# Altium schematic sheet Sata_SSD4.SchDoc(Sata_SSD4)
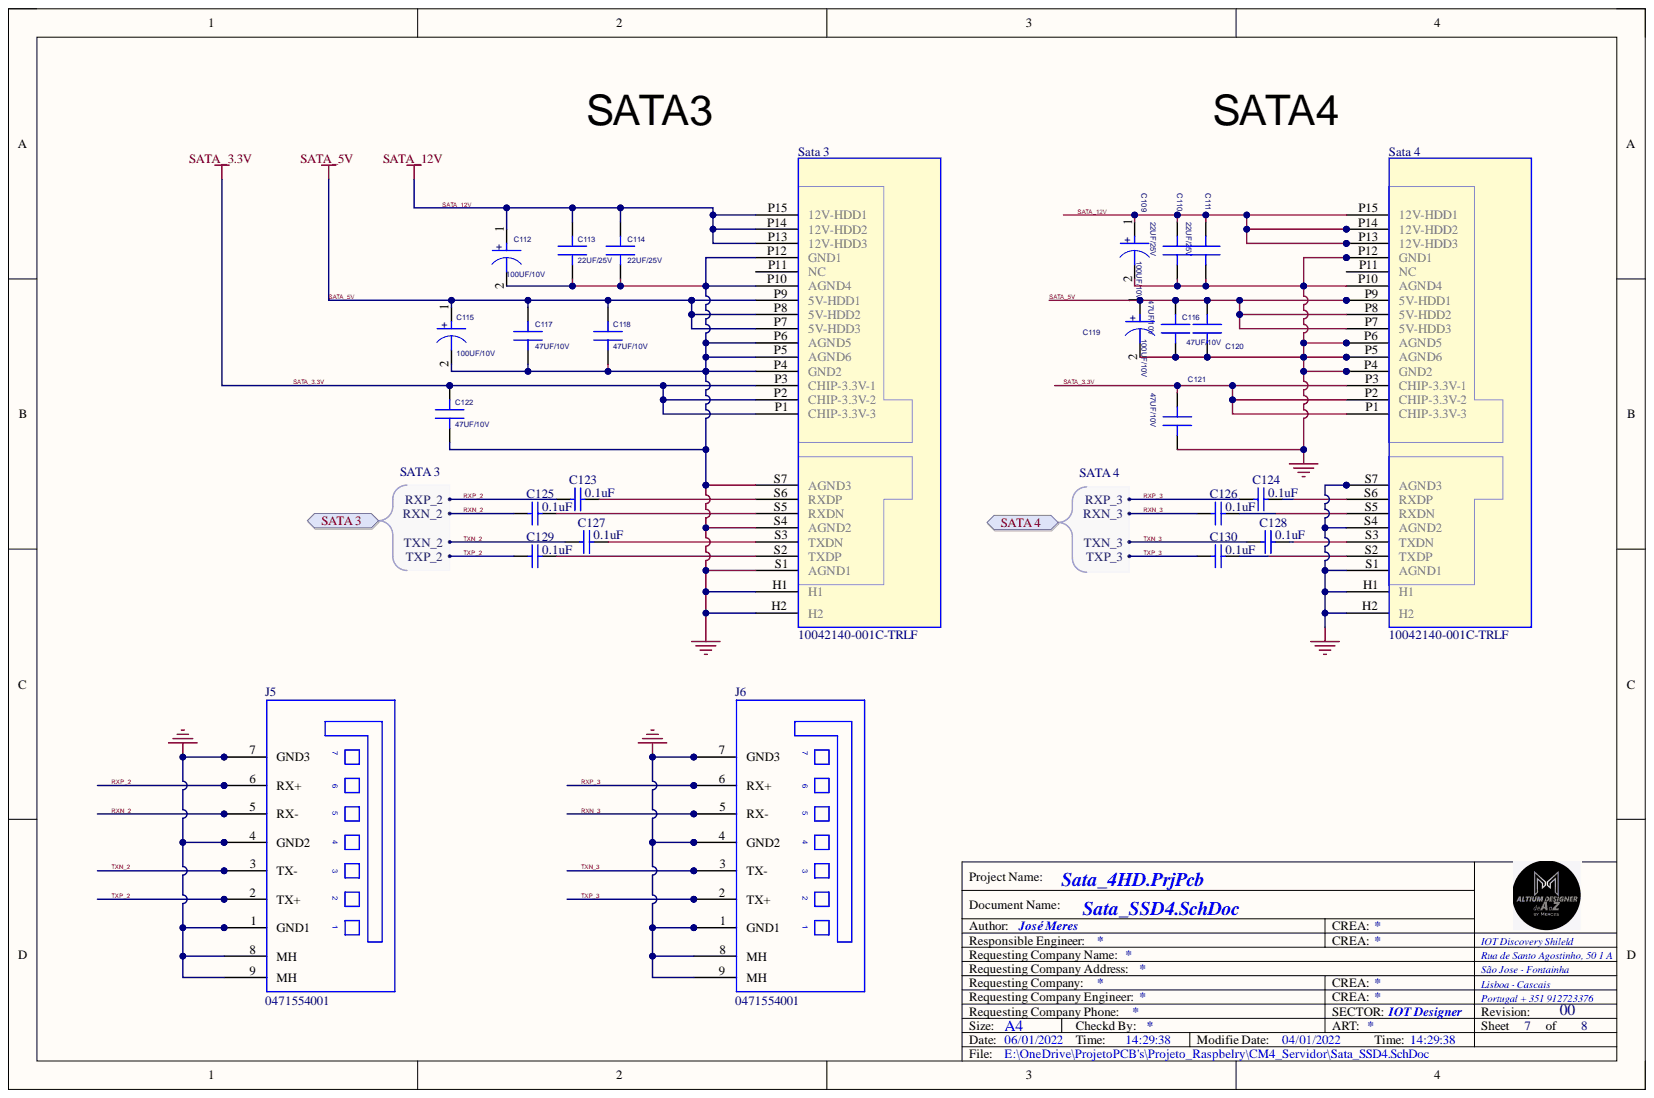
[diagram p0: Sata_SSD4.SchDoc]

## Parts
C109  100UF/10V  [Capacitor SMD Eletrolitic-D8.0x10]
C110  22UF/25V  [C0805-ROUND]
C111  22UF/25V  [C0805-ROUND]
C112  100UF/10V  [Capacitor SMD Eletrolitic-D8.0x10]
C113  22UF/25V  [C0805-ROUND]
C114  22UF/25V  [C0805-ROUND]
C115  100UF/10V  [Capacitor SMD Eletrolitic-D8.0x10]
C116  47UF/10V  [C0805-ROUND]
C117  47UF/10V  [C0805-ROUND]
C118  47UF/10V  [C0805-ROUND]
C119  100UF/10V  [Capacitor SMD Eletrolitic-D8.0x10]
C120  47UF/10V  [C0805-ROUND]
C121  47UF/10V  [C0805-ROUND]
C122  47UF/10V  [C0805-ROUND]
C123  value 0.1uF  [C0201-ROUND]  (hidden comment: GRM155R71A104KA01D)
C124  value 0.1uF  [C0201-ROUND]  (hidden comment: GRM155R71A104KA01D)
C125  value 0.1uF  [C0201-ROUND]  (hidden comment: GRM155R71A104KA01D)
C126  value 0.1uF  [C0201-ROUND]  (hidden comment: GRM155R71A104KA01D)
C127  value 0.1uF  [C0201-ROUND]  (hidden comment: GRM155R71A104KA01D)
C128  value 0.1uF  [C0201-ROUND]  (hidden comment: GRM155R71A104KA01D)
C129  value 0.1uF  [C0201-ROUND]  (hidden comment: GRM155R71A104KA01D)
C130  value 0.1uF  [C0201-ROUND]  (hidden comment: GRM155R71A104KA01D)
J5  0471554001  [SATA_VERTICAL]
J6  0471554001  [SATA_VERTICAL]
Sata_3  10042140-001C-TRLF  [sata_22p_smd_h6_h9]
Sata_4  10042140-001C-TRLF  [sata_22p_smd_h6_h9]

## Ports
SATA 3, SATA 3.RXN_2, SATA 3.RXP_2, SATA 3.TXN_2, SATA 3.TXP_2, SATA 4, SATA 4.RXN_3, SATA 4.RXP_3, SATA 4.TXN_3, SATA 4.TXP_3

## Nets
GND: C109.2 C110.2 C111.2 C112.2 C113.2 C114.2 C115.2 C116.2 C117.2 C118.2 C119.2 C120.2 C121.2 C122.2 J5.1(GND1) J5.4(GND2) J5.7(GND3) J5.8(MH) J5.9(MH) J6.1(GND1) J6.4(GND2) J6.7(GND3) J6.8(MH) J6.9(MH) Sata_3.H1 Sata_3.H2 Sata_3.P4(GND2) Sata_3.P5(AGND6) Sata_3.P6(AGND5) Sata_3.P10(AGND4) Sata_3.P12(GND1) Sata_3.S1(AGND1) Sata_3.S4(AGND2) Sata_3.S7(AGND3) Sata_4.H1 Sata_4.H2 Sata_4.P4(GND2) Sata_4.P5(AGND6) Sata_4.P6(AGND5) Sata_4.P10(AGND4) Sata_4.P12(GND1) Sata_4.S1(AGND1) Sata_4.S4(AGND2) Sata_4.S7(AGND3)
RXN_2: C125.2 J5.5(RX-) ; port SATA 3
RXN_3: C126.2 J6.5(RX-) ; port SATA 4
RXP_2: C123.2 J5.6(RX+) ; port SATA 3
RXP_3: C124.2 J6.6(RX+) ; port SATA 4
SATA_3.3V: C121.1 C122.1 Sata_3.P1(CHIP-3.3V-3) Sata_3.P2(CHIP-3.3V-2) Sata_3.P3(CHIP-3.3V-1) Sata_4.P1(CHIP-3.3V-3) Sata_4.P2(CHIP-3.3V-2) Sata_4.P3(CHIP-3.3V-1)
SATA_5V: C115.1 C116.1 C117.1 C118.1 C119.1 C120.1 Sata_3.P7(5V-HDD3) Sata_3.P8(5V-HDD2) Sata_3.P9(5V-HDD1) Sata_4.P7(5V-HDD3) Sata_4.P8(5V-HDD2) Sata_4.P9(5V-HDD1)
SATA_12V: C109.1 C110.1 C111.1 C112.1 C113.1 C114.1 Sata_3.P13(12V-HDD3) Sata_3.P14(12V-HDD2) Sata_3.P15(12V-HDD1) Sata_4.P13(12V-HDD3) Sata_4.P14(12V-HDD2) Sata_4.P15(12V-HDD1)
TXN_2: C127.2 J5.3(TX-) ; port SATA 3
TXN_3: C128.2 J6.3(TX-) ; port SATA 4
TXP_2: C129.2 J5.2(TX+) ; port SATA 3
TXP_3: C130.2 J6.2(TX+) ; port SATA 4
NetC123_1: C123.1 Sata_3.S6(RXDP)
NetC124_1: C124.1 Sata_4.S6(RXDP)
NetC125_1: C125.1 Sata_3.S5(RXDN)
NetC126_1: C126.1 Sata_4.S5(RXDN)
NetC127_1: C127.1 Sata_3.S3(TXDN)
NetC128_1: C128.1 Sata_4.S3(TXDN)
NetC129_1: C129.1 Sata_3.S2(TXDP)
NetC130_1: C130.1 Sata_4.S2(TXDP)

Not connected: Sata_3 P11(NC); Sata_4 P11(NC)

## Library data of the parts
C109 C112 C115 C119 = CP_0: PARTS: 1; %182%%238%%182%%168%%185%%164%%215%%247%%181%%231%%209%%185%(V): 25; %195%%232%%202%%246%: 非固体SMD铝电容-25V-220UF-+/-20%-8*10.5-8.3*8.3MM-极性-105DEGC-1000H-SMD-温度敏感器件-工艺耐温250DEGC,5S; %208%%161%%192%%224%%195%%251%%179%%198%: 非固体SMD铝电容; CODE: 8120004; COMPONENT_HIGH_MAX: 10.5MM; DEVICE: EMVE250ADA221MHA0G; LEVEL: STD; OriginalSymbolOrigin: (0,30); PCB Footprint: ct7343; PKG_TYPE: SCAPC2-280-327; Component Kind: Standard
C110 C113 C116 C117 C121 C122 = C_0_Dup2: PARTS: 1; %182%%238%%182%%168%%185%%164%%215%%247%%181%%231%%209%%185%(V): 25; %195%%232%%202%%246%: SMD陶瓷电容-25V-22000NF-+/-10%-X7R-1210-所有; %208%%161%%192%%224%%195%%251%%179%%198%: SMD陶瓷电容; CODE: 8070825; COMPONENT_HIGH_MAX: 2.7MM; DEVICE: CETMK325B7226KM_T; LEVEL: STD; OriginalSymbolOrigin: (0,30); PCB Footprint: SC1206; PINSWAP: (A,B); Component Kind: Standard; PKG_TYPE: SC1206
C111 C114 C118 C120 = C_0_Dup2: PARTS: 1; %182%%238%%182%%168%%185%%164%%215%%247%%181%%231%%209%%185%(V): 25; %195%%232%%202%%246%: SMD陶瓷电容-25V-22000NF-+/-10%-X7R-1210-所有; %208%%161%%192%%224%%195%%251%%179%%198%: SMD陶瓷电容; CODE: 8070825; COMPONENT_HIGH_MAX: 2.7MM; DEVICE: CETMK325B7226KM_T; LEVEL: STD; OriginalSymbolOrigin: (0,30); PCB Footprint: SC1206; PINSWAP: (A,B); PKG_TYPE: SC1210; Component Kind: Standard
C123-C130 = Capacitor: Chipe CapacitorSMD; Manufacturers: Murata; Kind: 100nF; Precision (%): 10%; Tensão: 10V; Versão: Basica/Completa; Case: SMD - 0201; Component Kind: Standard; Manufacturer P/N: GRM155R71A104KA01D; Published: 30/11/2017; Library Name: Modulos_Xbee.SchLib; Publisher: Merces
J5 J6 = 0471554001: CONN SATA HDR 7POS PCB VERT; Manufacturer: Molex Inc; .Checked PCB: used; Connector Style: Header, Shrouded; Number of Positions: 7; .Checked SCH: used; Category: Connectors, Interconnects; Component Kind: Standard; ComponentLink1Description: http://www.molex.com/elqNow/elqRedir.htm?ref=http://www.molex.com/pdm_docs/sd/471554001_sd.pdf; ComponentLink1URL: http://www.molex.com/elqNow/elqRedir.htm?ref=http://www.molex.com/pdm_docs/sd/471554001_sd.pdf; Connector Type: SATA; Contact Finish: Gold; Contact Finish Thickness: Flash; Features: Board Lock; Manufacturer Part Number: 0471554001; Mounting Type: Through Hole; Packaging: Tray; Pricing 1: 1=1.37, 10=1.065, 25=0.9928, 50=0.9026, 100=0.8123, 250=0.68592, 500=0.6498, 1000=0.5776, 2500=0.48013 (USD); RoHS: RoHS Compliant; Stock 1: 8065; Supplier 1: Digi-Key; Supplier Part Number 1: WM4059-ND; Termination: Solder; Library Name: Merces_Library.SchLib
Sata_3 Sata_4 = SATA 5+12P: Component Kind: Standard; PCB Footprint: sata_22p_smd_h6_h9; Library Name: Merces_Library.SchLib
